# Altium schematic sheet UniBoard-V5.SchDoc(UniBoard-V5)
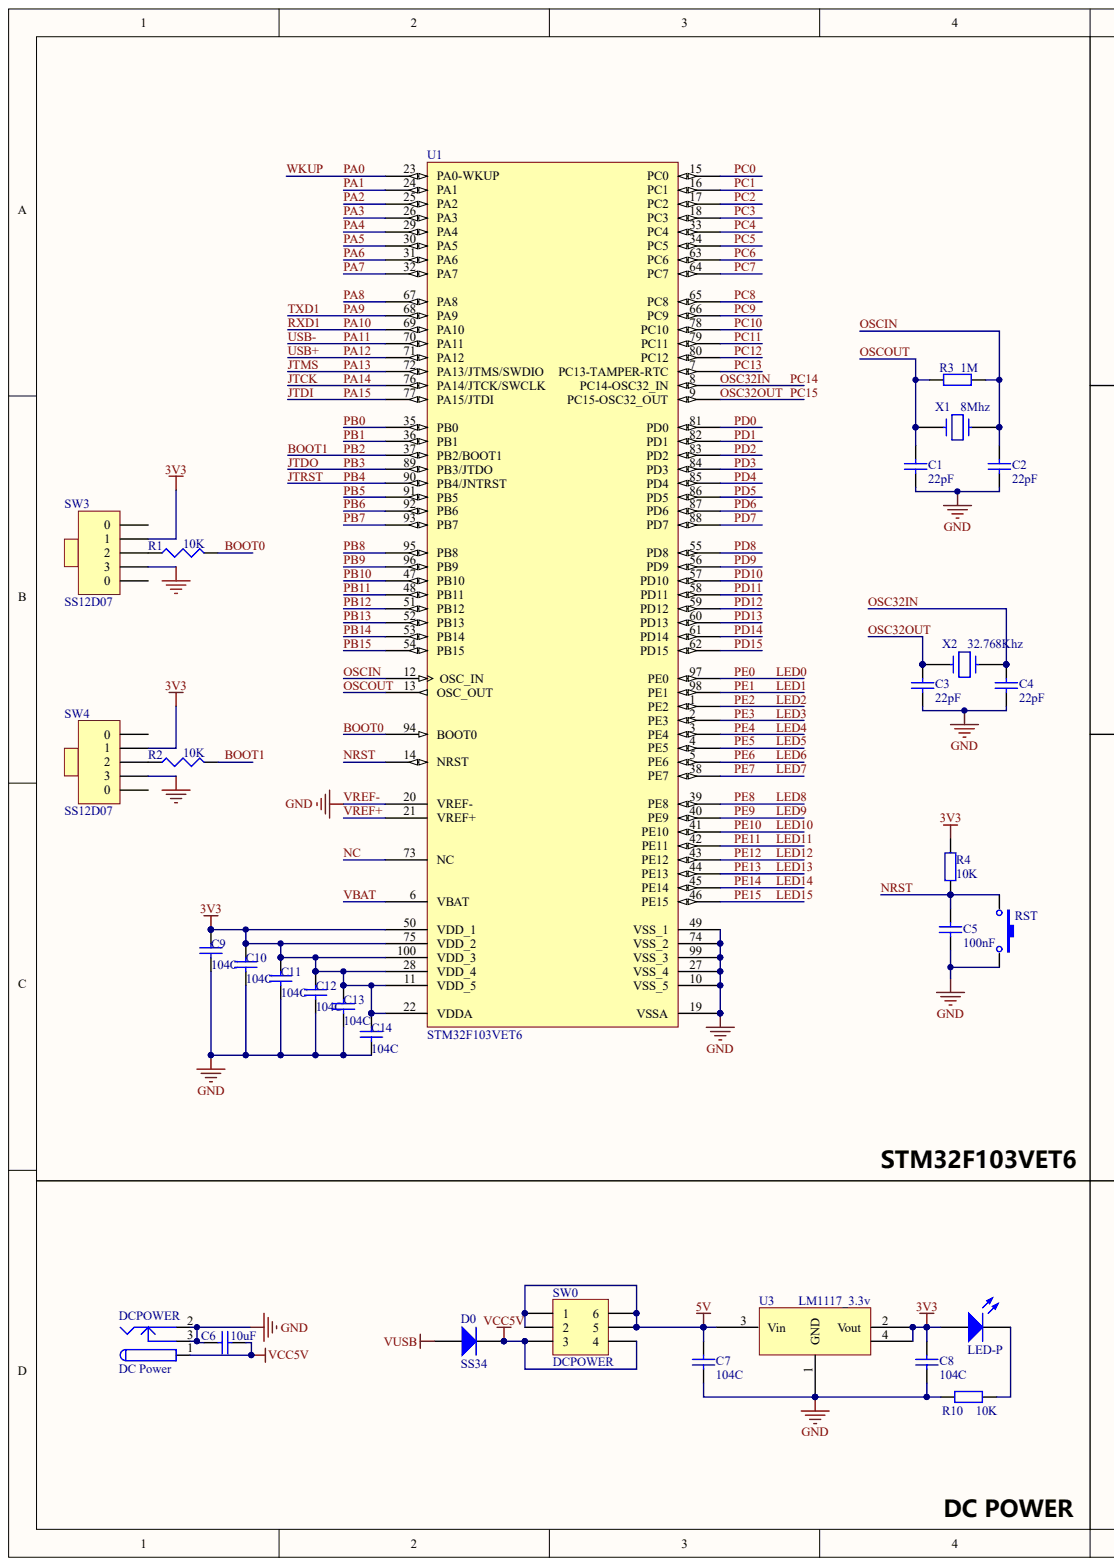
[diagram p0: UniBoard-V5.SchDoc, left]
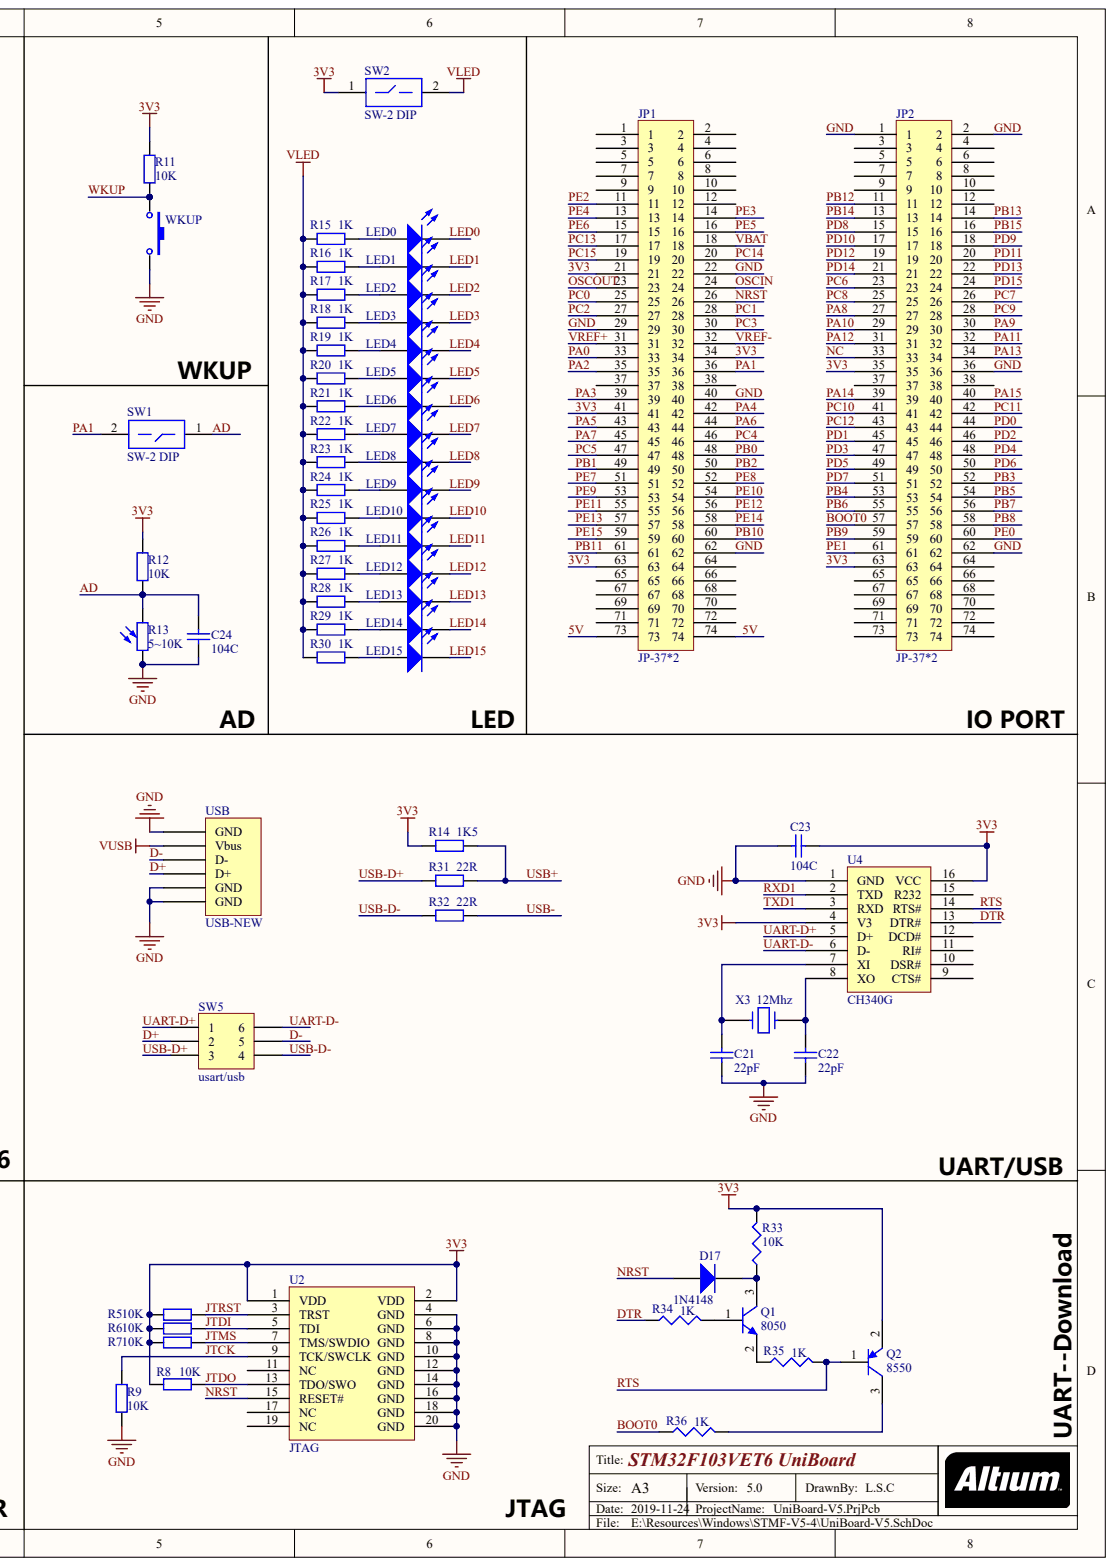
[diagram p1: UniBoard-V5.SchDoc, right]

## Parts
C1  value 22pF  (hidden comment: Cap)
C2  value 22pF  (hidden comment: Cap)
C3  value 22pF  (hidden comment: Cap)
C4  value 22pF  (hidden comment: Cap)
C5  value 100nF  (hidden comment: Cap)
C6  value 10uF  (hidden comment: Cap)
C7  value 104C  (hidden comment: Cap)
C8  value 104C  (hidden comment: Cap)
C9  value 104C  (hidden comment: Cap)
C10  value 104C  (hidden comment: Cap)
C11  value 104C  (hidden comment: Cap)
C12  value 104C  (hidden comment: Cap)
C13  value 104C  (hidden comment: Cap)
C14  value 104C  (hidden comment: Cap)
C21  value 22pF  (hidden comment: Cap)
C22  value 22pF  (hidden comment: Cap)
C23  value 104C  (hidden comment: Cap)
C24  value 104C  (hidden comment: Cap)
D0  SS34
D17  1N4148
DCPOWER  DC Power
JP1  JP-37*2
JP2  JP-37*2
LED0  LED
LED1  LED
LED2  LED
LED3  LED
LED4  LED
LED5  LED
LED6  LED
LED7  LED
LED8  LED
LED9  LED
LED10  LED
LED11  LED
LED12  LED
LED13  LED
LED14  LED
LED15  LED
LED-P  LED
Q1  8050
Q2  8550
R1  10K
R2  10K
R3  value 1M  (hidden comment: resistor)
R4  value 10K  (hidden comment: resistor)
R5  value 10K  (hidden comment: resistor)
R6  value 10K  (hidden comment: resistor)
R7  value 10K  (hidden comment: resistor)
R8  value 10K  (hidden comment: resistor)
R9  value 10K  (hidden comment: resistor)
R10  value 10K  (hidden comment: resistor)
R11  value 10K  (hidden comment: resistor)
R12  value 10K  (hidden comment: resistor)
R13  value 5~10K  (hidden comment: resistor -light)
R14  value 1K5  (hidden comment: resistor)
R15  value 1K  (hidden comment: resistor)
R16  value 1K  (hidden comment: resistor)
R17  value 1K  (hidden comment: resistor)
R18  value 1K  (hidden comment: resistor)
R19  value 1K  (hidden comment: resistor)
R20  value 1K  (hidden comment: resistor)
R21  value 1K  (hidden comment: resistor)
R22  value 1K  (hidden comment: resistor)
R23  value 1K  (hidden comment: resistor)
R24  value 1K  (hidden comment: resistor)
R25  value 1K  (hidden comment: resistor)
R26  value 1K  (hidden comment: resistor)
R27  value 1K  (hidden comment: resistor)
R28  value 1K  (hidden comment: resistor)
R29  value 1K  (hidden comment: resistor)
R30  value 1K  (hidden comment: resistor)
R31  value 22R  (hidden comment: resistor)
R32  value 22R  (hidden comment: resistor)
R33  10K
R34  1K
R35  1K
R36  1K
RST  Button -4  (value not drawn)
SW0  DCPOWER
SW1  SW-2 DIP
SW2  SW-2 DIP
SW3  SS12D07
SW4  SS12D07
SW5  usart/usb
U1  STM32F103VET6
U2  JTAG
U3  LM1117_3.3v
U4  CH340G
USB  USB-NEW
WKUP  Button -4  (value not drawn)
X1  value 8Mhz  (hidden comment: 16MHz)
X2  value 32.768Khz  (hidden comment: 16MHz)
X3  value 12Mhz  (hidden comment: 16MHz)

## Nets
VCC5V: C6.1 D0.2 DCPOWER.1 SW0.3 SW0.4
VLED: R15.1 R16.1 R17.1 R18.1 R19.1 R20.1 R21.1 R22.1 R23.1 R24.1 R25.1 R26.1 R27.1 R28.1 R29.1 R30.1 SW2.2
VUSB: D0.1 USB.Vbus
3V3: C8.1 C9.2 C10.2 C11.2 C12.2 C13.2 C14.2 C23.1 JP1.21 JP1.34 JP1.41 JP1.63 JP2.35 JP2.63 LED-P.1 Q2.2 R4.2 R5.2 R6.2 R7.2 R8.2 R11.2 R12.2 R14.2 R33.2 SW2.1 SW3.1 SW4.1 U1.11(VDD_5) U1.22(VDDA) U1.28(VDD_4) U1.50(VDD_1) U1.75(VDD_2) U1.100(VDD_3) U2.1(VDD) U2.2(VDD) U3.2(Vout) U3.4 U4.4(V3) U4.16(VCC)
5V: C7.1 JP1.73 JP1.74 SW0.1 SW0.2 SW0.5 SW0.6 U3.3(Vin)
AD: C24.1 R12.1 R13.2 SW1.1
BOOT0: JP2.57 R1.2 R36.1 U1.94(BOOT0)
BOOT1: JP1.50 R2.2 U1.37(PB2/BOOT1)
D+: SW5.2 USB.D+
D-: SW5.5 USB.D-
DTR: R34.1 U4.13(DTR#)
GND: C1.1 C2.1 C3.1 C4.1 C5.1 C6.2 C7.2 C8.2 C9.1 C10.1 C11.1 C12.1 C13.1 C14.1 C21.1 C22.1 C23.2 C24.2 DCPOWER.2 DCPOWER.3 JP1.22 JP1.29 JP1.32 JP1.40 JP1.62 JP2.1 JP2.2 JP2.36 JP2.62 R9.1 R10.2 R13.1 RST.2 SW3.3 SW4.3 U1.10(VSS_5) U1.19(VSSA) U1.20(VREF-) U1.27(VSS_4) U1.49(VSS_1) U1.74(VSS_2) U1.99(VSS_3) U2.4(GND) U2.6(GND) U2.8(GND) U2.10(GND) U2.12(GND) U2.14(GND) U2.16(GND) U2.18(GND) U2.20(GND) U3.1(GND) U4.1(GND) USB.GND WKUP.2
JTCK: JP2.39 R9.2 U1.76(PA14/JTCK/SWCLK) U2.9(TCK/SWCLK)
JTDI: JP2.40 R6.1 U1.77(PA15/JTDI) U2.5(TDI)
JTDO: JP2.52 R8.1 U1.89(PB3/JTDO) U2.13(TDO/SWO)
JTMS: JP2.34 R7.1 U1.72(PA13/JTMS/SWDIO) U2.7(TMS/SWDIO)
JTRST: JP2.53 R5.1 U1.90(PB4/JNTRST) U2.3(TRST)
LED0: JP2.60 LED0.2 U1.97(PE0)
LED1: JP2.61 LED1.2 U1.98(PE1)
LED2: JP1.11 LED2.2 U1.1(PE2)
LED3: JP1.14 LED3.2 U1.2(PE3)
LED4: JP1.13 LED4.2 U1.3(PE4)
LED5: JP1.16 LED5.2 U1.4(PE5)
LED6: JP1.15 LED6.2 U1.5(PE6)
LED7: JP1.51 LED7.2 U1.38(PE7)
LED8: JP1.52 LED8.2 U1.39(PE8)
LED9: JP1.53 LED9.2 U1.40(PE9)
LED10: JP1.54 LED10.2 U1.41(PE10)
LED11: JP1.55 LED11.2 U1.42(PE11)
LED12: JP1.56 LED12.2 U1.43(PE12)
LED13: JP1.57 LED13.2 U1.44(PE13)
LED14: JP1.58 LED14.2 U1.45(PE14)
LED15: JP1.59 LED15.2 U1.46(PE15)
NC: JP2.33 U1.73(NC)
NRST: C5.2 D17.1 JP1.26 R4.1 RST.1 U1.14(NRST) U2.15(RESET#)
OSC32IN: C4.2 JP1.20 U1.8(PC14-OSC32_IN) X2.2
OSC32OUT: C3.2 JP1.19 U1.9(PC15-OSC32_OUT) X2.1
OSCIN: C2.2 JP1.24 R3.1 U1.12(OSC_IN) X1.2
OSCOUT: C1.2 JP1.23 R3.2 U1.13(OSC_OUT) X1.1
PA0: JP1.33 R11.1 U1.23(PA0-WKUP) WKUP.1
PA1: JP1.36 SW1.2 U1.24(PA1)
PA2: JP1.35 U1.25(PA2)
PA3: JP1.39 U1.26(PA3)
PA4: JP1.42 U1.29(PA4)
PA5: JP1.43 U1.30(PA5)
PA6: JP1.44 U1.31(PA6)
PA7: JP1.45 U1.32(PA7)
PA8: JP2.27 U1.67(PA8)
PA9: JP2.30 U1.68(PA9) U4.3(RXD)
PA10: JP2.29 U1.69(PA10) U4.2(TXD)
PA11: JP2.32 R32.1 U1.70(PA11)
PA12: JP2.31 R14.1 R31.1 U1.71(PA12)
PB0: JP1.48 U1.35(PB0)
PB1: JP1.49 U1.36(PB1)
PB5: JP2.54 U1.91(PB5)
PB6: JP2.55 U1.92(PB6)
PB7: JP2.56 U1.93(PB7)
PB8: JP2.58 U1.95(PB8)
PB9: JP2.59 U1.96(PB9)
PB10: JP1.60 U1.47(PB10)
PB11: JP1.61 U1.48(PB11)
PB12: JP2.11 U1.51(PB12)
PB13: JP2.14 U1.52(PB13)
PB14: JP2.13 U1.53(PB14)
PB15: JP2.16 U1.54(PB15)
PC0: JP1.25 U1.15(PC0)
PC1: JP1.28 U1.16(PC1)
PC2: JP1.27 U1.17(PC2)
PC3: JP1.30 U1.18(PC3)
PC4: JP1.46 U1.33(PC4)
PC5: JP1.47 U1.34(PC5)
PC6: JP2.23 U1.63(PC6)
PC7: JP2.26 U1.64(PC7)
PC8: JP2.25 U1.65(PC8)
PC9: JP2.28 U1.66(PC9)
PC10: JP2.41 U1.78(PC10)
PC11: JP2.42 U1.79(PC11)
PC12: JP2.43 U1.80(PC12)
PC13: JP1.17 U1.7(PC13-TAMPER-RTC)
PD0: JP2.44 U1.81(PD0)
PD1: JP2.45 U1.82(PD1)
PD2: JP2.46 U1.83(PD2)
PD3: JP2.47 U1.84(PD3)
PD4: JP2.48 U1.85(PD4)
PD5: JP2.49 U1.86(PD5)
PD6: JP2.50 U1.87(PD6)
PD7: JP2.51 U1.88(PD7)
PD8: JP2.15 U1.55(PD8)
PD9: JP2.18 U1.56(PD9)
PD10: JP2.17 U1.57(PD10)
PD11: JP2.20 U1.58(PD11)
PD12: JP2.19 U1.59(PD12)
PD13: JP2.22 U1.60(PD13)
PD14: JP2.21 U1.61(PD14)
PD15: JP2.24 U1.62(PD15)
RTS: Q2.1 R35.2 U4.14(RTS#)
UART-D+: SW5.1 U4.5(D+)
UART-D-: SW5.6 U4.6(D-)
USB-D+: R31.2 SW5.3
USB-D-: R32.2 SW5.4
VBAT: JP1.18 U1.6(VBAT)
VREF+: JP1.31 U1.21(VREF+)
NetC21_2: C21.2 U4.7(XI) X3.1
NetC22_2: C22.2 U4.8(XO) X3.2
NetD17_2: D17.2 Q1.3 R33.1
NetLED0_1: LED0.1 R15.2
NetLED1_1: LED1.1 R16.2
NetLED2_1: LED2.1 R17.2
NetLED3_1: LED3.1 R18.2
NetLED4_1: LED4.1 R19.2
NetLED5_1: LED5.1 R20.2
NetLED6_1: LED6.1 R21.2
NetLED7_1: LED7.1 R22.2
NetLED8_1: LED8.1 R23.2
NetLED9_1: LED9.1 R24.2
NetLED10_1: LED10.1 R25.2
NetLED11_1: LED11.1 R26.2
NetLED12_1: LED12.1 R27.2
NetLED13_1: LED13.1 R28.2
NetLED14_1: LED14.1 R29.2
NetLED15_1: LED15.1 R30.2
NetLED-P_2: LED-P.2 R10.1
NetQ1_1: Q1.1 R34.2
NetQ1_2: Q1.2 R35.1
NetQ2_3: Q2.3 R36.2
NetR1_1: R1.1 SW3.2
NetR2_1: R2.1 SW4.2

Not connected: JP1 1 2 3 4 5 6 7 8 9 10 12 37 38 64 65 66 67 68 69 70 71 72; JP2 3 4 5 6 7 8 9 10 12 37 38 64 65 66 67 68 69 70 71 72 73 74; SW3 0; SW4 0; U2 11(NC) 17(NC) 19(NC); U4 9(CTS#) 10(DSR#) 11(RI#) 12(DCD#) 15(R232)

## Library data of the parts
C1-C14 C21-C24 D0 D17 DCPOWER JP1 JP2 LED0-LED15 LED-P Q1 Q2 R1-R36 RST SW0-SW5 U1-U4 USB WKUP X1-X3 = (no symbol name)
  C1-C14 C21-C24: Published: 8-Jun-2000; LatestRevisionDate: 17-Jul-2002; LatestRevisionNote: Re-released for DXP Platform.; PackageReference: RAD-0.3
  D17: Published: 8-Jun-2000; LatestRevisionDate: 17-Jul-2002; LatestRevisionNote: Re-released for DXP Platform.; DatasheetVersion: 1997; PackageReference: DO-35; Code_JEDEC: DO-204-AH; ComponentLink1Description: Manufacturer Link; ComponentLink1URL: http://www.fairchildsemi.com/; ComponentLink2Description: Datasheet; ComponentLink2URL: http://www.fairchildsemi.com/ds/1N%2F1N4148.pdf
  LED0-LED15 LED-P: Published: 8-Jun-2000; LatestRevisionDate: 08-Jul-2005; LatestRevisionNote: Mech Layer 1 removed.; PackageReference: LED-0
  Q1: Published: 8-Jun-2000; LatestRevisionDate: 15-Jan-2003; LatestRevisionNote: PCB Footprint 'BCY-W3/D4.7' replaced; DatasheetVersion: 1997; PackageVersion: Sep-1998; PackageReference: TO-92A; PackageDescription: TO, Flat Index; 3 In-Line, Axial Leads; Body Dia. 4.5mm; Leads 0.51 x 0.48 mm (max); ComponentLink1Description: Manufacturer Link; ComponentLink1URL: http://www.fairchildsemi.com/; ComponentLink2Description: Datasheet; ComponentLink2URL: http://www.fairchildsemi.com/ds/2N%2F2N3904.pdf
  Q2: Published: 8-Jun-2000; LatestRevisionDate: 15-Jan-2003; LatestRevisionNote: PCB Footprint 'BCY-W3/D4.7' replaced; DatasheetVersion: 1997; PackageVersion: Sep-1998; PackageReference: TO-92A; PackageDescription: TO, Flat Index; 3 In-Line, Axial Leads; Body Dia. 4.5mm; Leads 0.51 x 0.48 mm (max); ComponentLink1Description: Manufacturer Link; ComponentLink1URL: http://www.fairchildsemi.com/; ComponentLink2Description: Datasheet; ComponentLink2URL: http://www.fairchildsemi.com/ds/2N%2F2N3906.pdf
  U1: Published: 30-May-2008; DatasheetVersion: rev6, Mar-2008; PackageVersion: Mar-2008; PackageReference: LQFP100; PackageDescription: 100-Pin Low-Profile Quad Flat Pack, 14 x 14 mm Body, 0.5 mm Pitch (LQFP100); ComponentLink1Description: Manufacturer Link; ComponentLink1URL: www.st.com/stm32; ComponentLink2Description: Datasheet; ComponentLink2URL: http://www.st.com/stonline/products/literature/ds/13587.pdf
  C1-C14 C21-C24 D17 LED0-LED15 LED-P Q1 Q2 U1: Publisher: Altium Limited
